# Altium schematic sheet stm32f103fxx.SchDoc(stm32f103fxx)
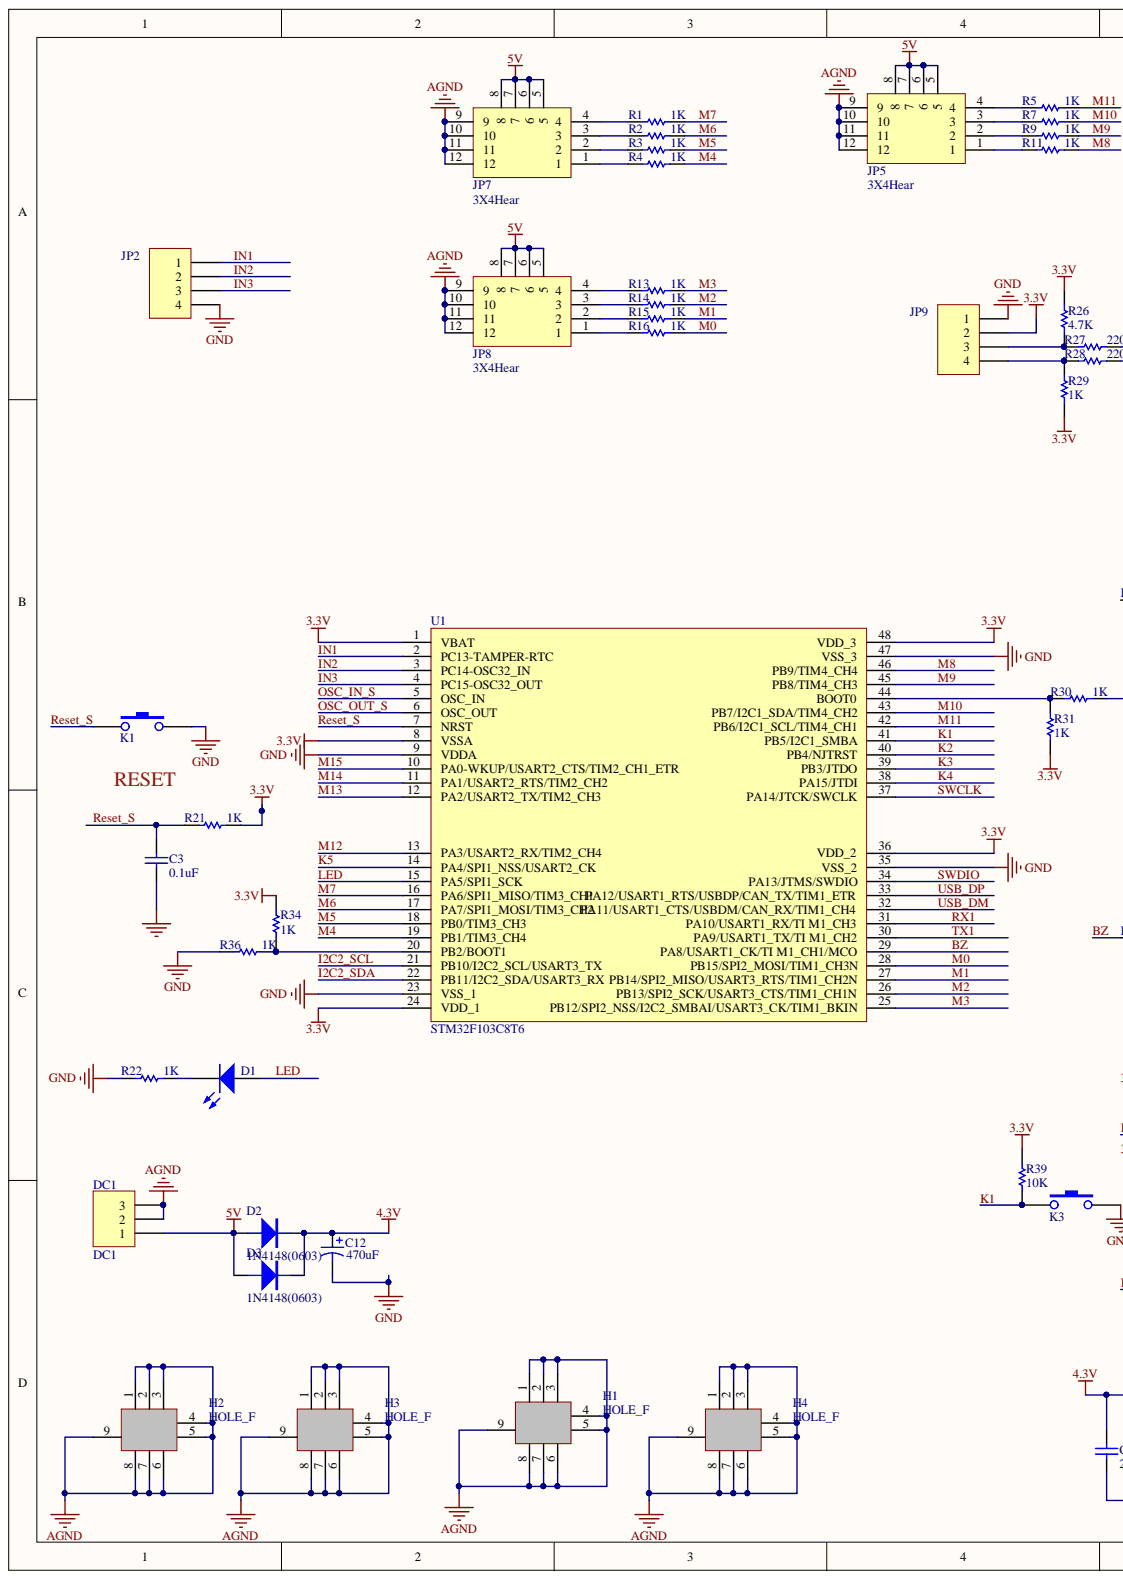
[diagram p0: stm32f103fxx.SchDoc, left]
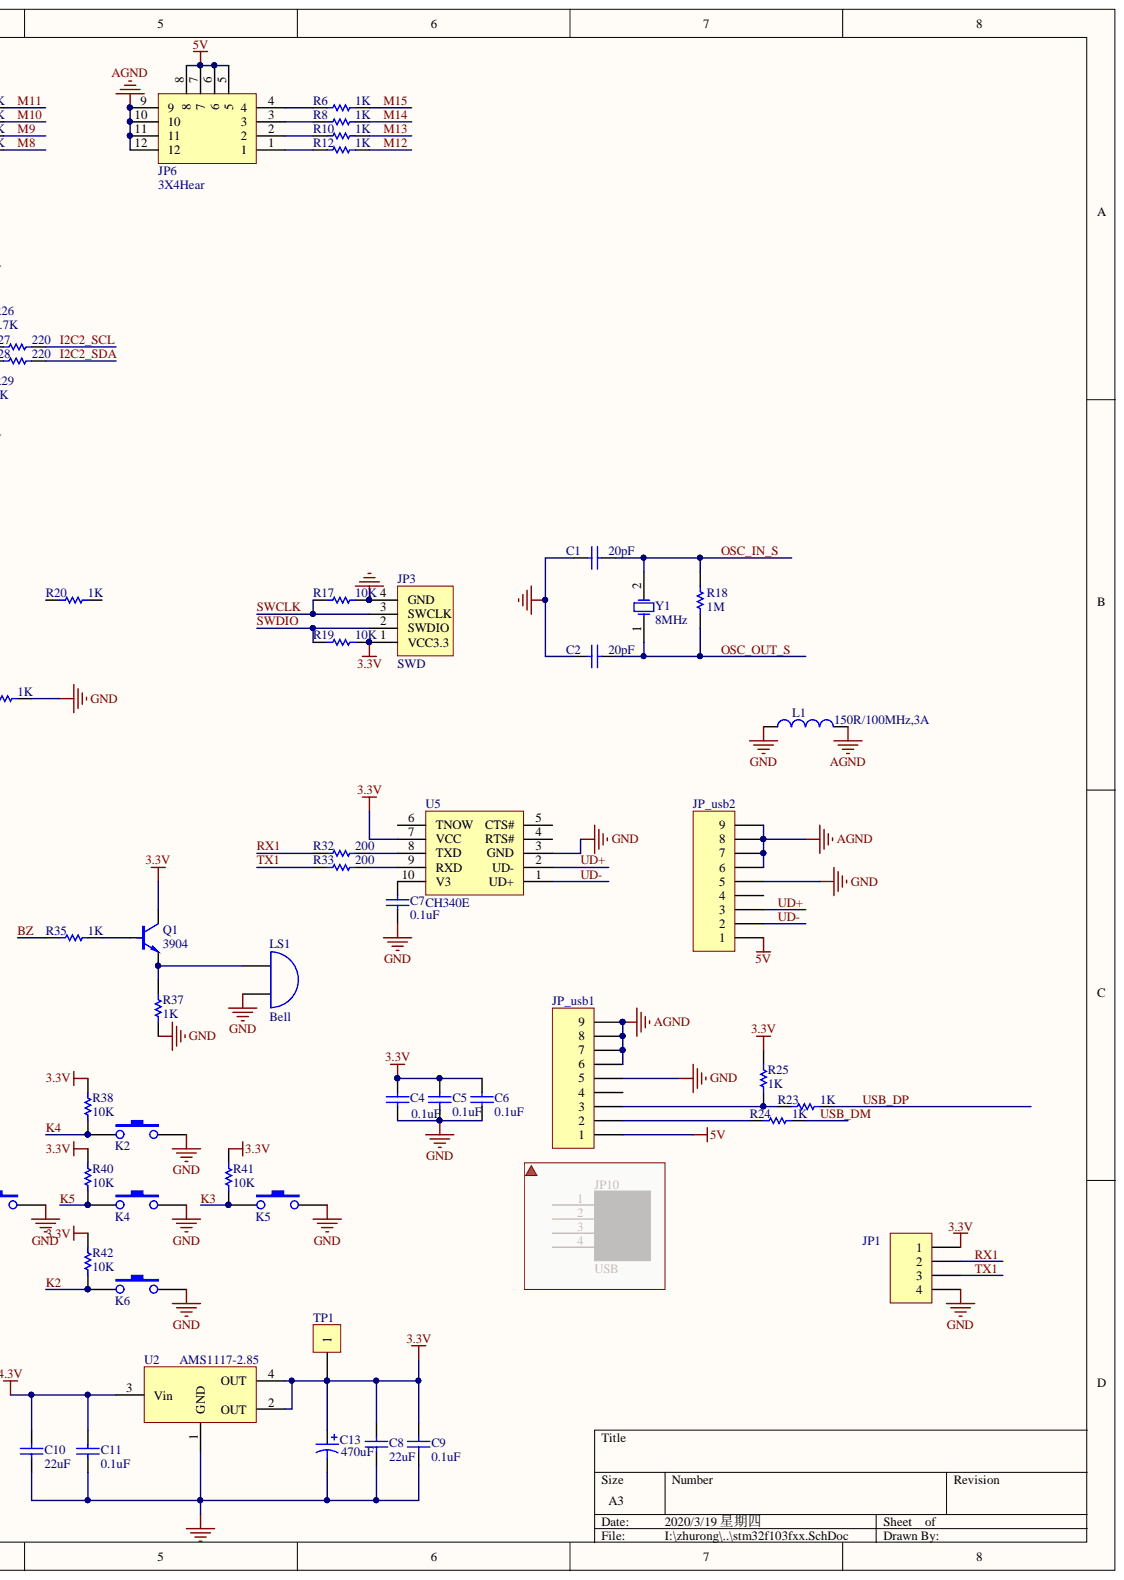
[diagram p1: stm32f103fxx.SchDoc, right]

## Parts
C1  20pF  [Cap-0603]
C2  20pF  [Cap-0603]
C3  0.1uF  [Cap-0603]
C4  0.1uF  [Cap-0603]
C5  0.1uF  [Cap-0603]
C6  0.1uF  [Cap-0603]
C7  0.1uF  [Cap-0603]
C8  22uF  [Res1-0603]
C9  0.1uF  [Cap-0603]
C10  22uF  [0805C]
C11  0.1uF  [Cap-0603]
C12  value 470uF  [RB-L1-6.2X11.2mm]  (hidden comment: Cap Pol1)
C13  value 470uF  [RB-L1-6.2X11.2mm]  (hidden comment: Cap Pol1)
D1  LED0  [0603LED]  (value not drawn)
D2  1N4148(0603)  [SOD-123]
D3  1N4148(0603)  [SOD-123]
DC1  DC1  [DC_5]
H1  HOLE_F  [HOLE]
H2  HOLE_F  [HOLE]
H3  HOLE_F  [HOLE]
H4  HOLE_F  [HOLE]
JP1  Header 4  [JP4]  (value not drawn)
JP2  Header 4  [JP4]  (value not drawn)
JP3  SWD  [JP4]
JP5  3X4Hear  [JP3X4]
JP6  3X4Hear  [JP3X4]
JP7  3X4Hear  [JP3X4]
JP8  3X4Hear  [JP3X4]
JP9  Header 4  [JP4]  (value not drawn)
JP_usb1  Header 9  [USB]  (value not drawn)
JP_usb2  Header 9  [USB]  (value not drawn)
K1  KEY  [TS_B005key]  (value not drawn)
K2  KEY  [TS_B005key]  (value not drawn)
K3  KEY  [TS_B005key]  (value not drawn)
K4  KEY  [TS_B005key]  (value not drawn)
K5  KEY  [TS_B005key]  (value not drawn)
K6  KEY  [TS_B005key]  (value not drawn)
L1  150R/100MHz,3A  [0603L]
LS1  Bell  [PIN2]
Q1  3904  [SOT23]
R1  1K  [Res1-0603]
R2  1K  [Res1-0603]
R3  1K  [Res1-0603]
R4  1K  [Res1-0603]
R5  1K  [Res1-0603]
R6  1K  [Res1-0603]
R7  1K  [Res1-0603]
R8  1K  [Res1-0603]
R9  1K  [Res1-0603]
R10  1K  [Res1-0603]
R11  1K  [Res1-0603]
R12  1K  [Res1-0603]
R13  1K  [Res1-0603]
R14  1K  [Res1-0603]
R15  1K  [Res1-0603]
R16  1K  [Res1-0603]
R17  10K  [Res1-0603]
R18  1M  [Res1-0603]
R19  10K  [Res1-0603]
R20  1K  [Res1-0603]
R21  1K  [Res1-0603]
R22  1K  [Res1-0603]
R23  1K  [Res1-0603]
R24  1K  [Res1-0603]
R25  1K  [Res1-0603]
R26  value 4.7K  [Res1-0603]  (hidden comment: 1K)
R27  value 220  [Res1-0603]  (hidden comment: 200)
R28  1K  value 220  [Res1-0603]
R29  4.7K  value 1K  [Res1-0603]
R30  1K  [Res1-0603]
R31  1K  [Res1-0603]
R32  value 200  [Res1-0603]  (hidden comment: 1K)
R33  value 200  [Res1-0603]  (hidden comment: 1K)
R34  1K  [Res1-0603]
R35  1K  [Res1-0603]
R36  1K  [Res1-0603]
R37  1K  [Res1-0603]
R38  value 10K  [Res1-0603]  (hidden comment: 1K)
R39  value 10K  [Res1-0603]  (hidden comment: 1K)
R40  value 10K  [Res1-0603]  (hidden comment: 1K)
R41  value 10K  [Res1-0603]  (hidden comment: 1K)
R42  value 10K  [Res1-0603]  (hidden comment: 1K)
TP1  TP  [TP]
U1  STM32F103C8T6  [LQFP48/STM]
U2  AMS1117-2.85  [SOT223_AMS1117_GX]
U5  CH340E  [MSOP10]
Y1  8MHz  [XLXT]

## Nets
3.3V: C4.1 C5.1 C6.1 C8.1 C9.2 C13.1 JP1.1 JP3.1(VCC3.3) JP9.2 Q1.C R19.1 R21.1 R25.1 R26.2 R29.1 R31.1 R34.1 R38.2 R39.2 R40.2 R41.2 R42.2 TP1.1 U1.1(VBAT) U1.8(VSSA) U1.24(VDD_1) U1.36(VDD_2) U1.48(VDD_3) U2.2(OUT) U2.4(OUT) U5.7(VCC)
4.3V: C10.1 C11.2 C12.1 D2.2 D3.2 U2.3(Vin)
5V: D2.1 D3.1 DC1.1 JP5.5 JP5.6 JP5.7 JP5.8 JP6.5 JP6.6 JP6.7 JP6.8 JP7.5 JP7.6 JP7.7 JP7.8 JP8.5 JP8.6 JP8.7 JP8.8 JP_usb1.1 JP_usb2.1
AGND: DC1.2 DC1.3 H1.1 H1.2 H1.3 H1.4 H1.5 H1.6 H1.7 H1.8 H1.9 H2.1 H2.2 H2.3 H2.4 H2.5 H2.6 H2.7 H2.8 H2.9 H3.1 H3.2 H3.3 H3.4 H3.5 H3.6 H3.7 H3.8 H3.9 H4.1 H4.2 H4.3 H4.4 H4.5 H4.6 H4.7 H4.8 H4.9 JP5.9 JP5.10 JP5.11 JP5.12 JP6.9 JP6.10 JP6.11 JP6.12 JP7.9 JP7.10 JP7.11 JP7.12 JP8.9 JP8.10 JP8.11 JP8.12 JP_usb1.6 JP_usb1.7 JP_usb1.8 JP_usb1.9 JP_usb2.6 JP_usb2.7 JP_usb2.8 JP_usb2.9 L1.2
GND: C1.1 C2.1 C3.2 C4.2 C5.2 C6.2 C7.2 C8.2 C9.1 C10.2 C11.1 C12.2 C13.2 JP1.4 JP2.4 JP3.4(GND) JP9.1 JP_usb1.5 JP_usb2.5 K1.2 K2.2 K3.2 K4.2 K5.2 K6.2 L1.1 LS1.2 R17.1 R22.1 R30.2 R36.2 R37.1 U1.9(VDDA) U1.23(VSS_1) U1.35(VSS_2) U1.47(VSS_3) U2.1(GND) U5.3(GND)
BZ: R35.1 U1.29(PA8/USART1_CK/TI_M1_CH1/MCO)
I2C2_SCL: R27.2 U1.21(PB10/I2C2_SCL/USART3_TX)
I2C2_SDA: R28.2 U1.22(PB11/I2C2_SDA/USART3_RX)
IN1: JP2.1 U1.2(PC13-TAMPER-RTC)
IN2: JP2.2 U1.3(PC14-OSC32_IN)
IN3: JP2.3 U1.4(PC15-OSC32_OUT)
K1: K3.1 R39.1 U1.41(PB5/I2C1_SMBA)
K2: K6.1 R42.1 U1.40(PB4/NJTRST)
K3: K5.1 R41.1 U1.39(PB3/JTDO)
K4: K2.1 R38.1 U1.38(PA15/JTDI)
K5: K4.1 R40.1 U1.14(PA4/SPI1_NSS/USART2_CK)
LED: D1.1 U1.15(PA5/SPI1_SCK)
M0: R16.2 U1.28(PB15/SPI2_MOSI/TIM1_CH3N)
M1: R15.2 U1.27(PB14/SPI2_MISO/USART3_RTS/TIM1_CH2N)
M2: R14.2 U1.26(PB13/SPI2_SCK/USART3_CTS/TIM1_CH1N)
M3: R13.2 U1.25(PB12/SPI2_NSS/I2C2_SMBAI/USART3_CK/TIM1_BKIN)
M4: R4.2 U1.19(PB1/TIM3_CH4)
M5: R3.2 U1.18(PB0/TIM3_CH3)
M6: R2.2 U1.17(PA7/SPI1_MOSI/TIM3_CH2)
M7: R1.2 U1.16(PA6/SPI1_MISO/TIM3_CH1)
M8: R11.2 U1.46(PB9/TIM4_CH4)
M9: R9.2 U1.45(PB8/TIM4_CH3)
M10: R7.2 U1.43(PB7/I2C1_SDA/TIM4_CH2)
M11: R5.2 U1.42(PB6/I2C1_SCL/TIM4_CH1)
M12: R12.2 U1.13(PA3/USART2_RX/TIM2_CH4)
M13: R10.2 U1.12(PA2/USART2_TX/TIM2_CH3)
M14: R8.2 U1.11(PA1/USART2_RTS/TIM2_CH2)
M15: R6.2 U1.10(PA0-WKUP/USART2_CTS/TIM2_CH1_ETR)
OSC_IN_S: C1.2 R18.2 U1.5(OSC_IN) Y1.2
OSC_OUT_S: C2.2 R18.1 U1.6(OSC_OUT) Y1.1
RESET_S: C3.1 K1.1 R21.2 U1.7(NRST)
RX1: JP1.2 R32.1 U1.31(PA10/USART1_RX/TI_M1_CH3)
SWCLK: JP3.3(SWCLK) R17.2 U1.37(PA14/JTCK/SWCLK)
SWDIO: JP3.2(SWDIO) R19.2 U1.34(PA13/JTMS/SWDIO)
TX1: JP1.3 R33.1 U1.30(PA9/USART1_TX/TI_M1_CH2)
UD+: JP_usb2.3 U5.2(UD-)
UD-: JP_usb2.2 U5.1(UD+)
USB_DM: R24.2 U1.32(PA11/USART1_CTS/USBDM/CAN_RX/TIM1_CH4)
USB_DP: R23.2 U1.33(PA12/USART1_RTS/USBDP/CAN_TX/TIM1_ETR)
NetC7_1: C7.1 U5.10(V3)
NetD1_2: D1.2 R22.2
NetJP5_1: JP5.1 R11.1
NetJP5_2: JP5.2 R9.1
NetJP5_3: JP5.3 R7.1
NetJP5_4: JP5.4 R5.1
NetJP6_1: JP6.1 R12.1
NetJP6_2: JP6.2 R10.1
NetJP6_3: JP6.3 R8.1
NetJP6_4: JP6.4 R6.1
NetJP7_1: JP7.1 R4.1
NetJP7_2: JP7.2 R3.1
NetJP7_3: JP7.3 R2.1
NetJP7_4: JP7.4 R1.1
NetJP8_1: JP8.1 R16.1
NetJP8_2: JP8.2 R15.1
NetJP8_3: JP8.3 R14.1
NetJP8_4: JP8.4 R13.1
NetJP9_3: JP9.3 R26.1 R27.1
NetJP9_4: JP9.4 R28.1 R29.2
NetJP_usb1_2: JP_usb1.2 R24.1
NetJP_usb1_3: JP_usb1.3 R23.1 R25.2
NetLS1_1: LS1.1 Q1.E R37.2
NetQ1_B: Q1.B R35.2
NetR30_1: R30.1 R31.2 U1.44(BOOT0)
NetR32_2: R32.2 U5.8(TXD)
NetR33_2: R33.2 U5.9(RXD)
NetR34_2: R34.2 R36.1 U1.20(PB2/BOOT1)

Not connected: JP_usb1 4; JP_usb2 4; R20 1 2; U5 4(RTS#) 5(CTS#) 6(TNOW)

## Library data of the parts
C1-C11 = Cap Semi: Component Kind: Standard; LatestRevisionDate: 29-May-2009; LatestRevisionNote: IPC-7351 Footprint Added.; Library Name: Miscellaneous Devices.IntLib; PackageDescription: Chip Capacitor; PackageReference: C1206; Published: 8-Jun-2000; Signal Integrity: Cap Semi; Simulation: CAP; Publisher: Altium Limited
  C1 C2: Description: 20pF; Value: 20pF
  C3-C7 C9 C11: Description: 0.1uF; Value: 0.1uF
  C8 C10: Description: 22uF; Value: 22uF
C12 C13 = Cap Pol1: Polarized Capacitor (Radial); Component Kind: Standard; LatestRevisionDate: 17-Jul-2002; LatestRevisionNote: Re-released for DXP Platform.; Library Name: Miscellaneous Devices.IntLib; PackageReference: RB7.6-15; Published: 8-Jun-2000; Signal Integrity: Cap Pol; Simulation: CAP; Publisher: Altium Limited
D1 = LED0: Typical INFRARED GaAs LED; Component Kind: Standard; LatestRevisionDate: 08-Jul-2005; LatestRevisionNote: Mech Layer 1 removed.; Library Name: Miscellaneous Devices.IntLib; PackageReference: LED-0; Published: 8-Jun-2000; Simulation: LED0; Publisher: Altium Limited
DC1 = Header 3: Header, 3-Pin; Component Kind: Standard; LatestRevisionDate: 17-Jul-2002; LatestRevisionNote: Re-released for DXP Platform.; Library Name: KBMC100-AB92A.IntLib; Publisher: Altium Limited
JP1 JP2 JP9 = Header 4: Header, 4-Pin; Component Kind: Standard; LatestRevisionDate: 17-Jul-2002; LatestRevisionNote: Re-released for DXP Platform.; Library Name: Miscellaneous Connectors.IntLib; Publisher: Altium Limited
JP3 = SWD: JTAG_SWD; : SWD; Component Kind: Standard; Library Name: 我的元件库.SCHLIB
JP_usb1 JP_usb2 = Header 9: Header, 9-Pin; Component Kind: Standard; LatestRevisionDate: 17-Jul-2002; LatestRevisionNote: Re-released for DXP Platform.; Library Name: Miscellaneous Connectors.IntLib; Publisher: Altium Limited
K1-K6 = SW-PB: Component Kind: Standard; Description: KEY
L1 = Inductor: Code_IEC: 1005; Code_JEITA: 0402; Component Kind: Standard; Description: 150R/100MHz,3A; LatestRevisionDate: 29-May-2009; LatestRevisionNote: IPC-7351 Footprint Added.; Library Name: Miscellaneous Devices.IntLib; PackageDescription: Chip Inductor; PackageReference: 0402-A; Published: 8-Jun-2000; Signal Integrity: Inductor; Simulation: INDUCTOR; Value: 150R/100MHz,3A; Publisher: Altium Limited
LS1 = Bell: Electrical Bell; Component Kind: Standard; LatestRevisionDate: 17-Jul-2002; LatestRevisionNote: Re-released for DXP Platform.; Library Name: Miscellaneous Devices.IntLib; PackageReference: PIN2; Published: 24-Mar-1999; Publisher: Altium Limited
Q1 = NPN: Component Kind: Standard; Library Name: KBAS100-AA41C.IntLib
R1-R26 R28 R30-R42 = Res1: Component Kind: Standard; LatestRevisionDate: 17-Jul-2002; LatestRevisionNote: Re-released for DXP Platform.; Library Name: Miscellaneous Devices.IntLib; PackageReference: AXIAL-0.3; Published: 8-Jun-2000; Signal Integrity: Res; Simulation: RESISTOR; Publisher: Altium Limited
  R1-R16 R20-R25 R30 R31 R34-R37: Description: 1K; Value: 1K
  R17 R19: Description: 10K; Value: 10K
  R18: Description: 1M; Value: 1M
  R26 R28 R32 R33 R38-R42: Description: 1K
R27 R29 = Res1: 1K; Component Kind: Standard; LatestRevisionDate: 17-Jul-2002; LatestRevisionNote: Re-released for DXP Platform.; Library Name: Miscellaneous Devices.IntLib; PackageReference: AXIAL-0.3; Published: 8-Jun-2000; Signal Integrity: Res; Simulation: RESISTOR; Publisher: Altium Limited
TP1 = TP: Test point; Component Kind: Standard; Library Name: huasu_wzw.SchLib
U1 = STMF103CB: STM103FCB; Component Kind: Standard; Package: LQFP48; Value: STM103FCB; Library Name: huasu_wzw.SchLib
U2 = ASM1117: Component Kind: Standard; Description: AMS1117-2.85; Package: SOT223; Library Name: huasu_wzw.SchLib
Y1 = XTAL: Component Kind: Standard; Description: 8MHz; LatestRevisionDate: 17-Jul-2002; LatestRevisionNote: Re-released for DXP Platform.; Library Name: Miscellaneous Devices.IntLib; PackageReference: R38; Published: 8-Jun-2000; Value: 8MHz; Publisher: Altium Limited
D2 D3 = 1N4148(0603): Component Kind: Standard; Library Name: 我的元件库.SCHLIB
H1-H4 = HOLE_F: Component Kind: Standard; Library Name: huasu_wzw.SchLib
JP5-JP8 = 3X4Hear: Component Kind: Standard; Library Name: pwmProject.SCHLIB
U5 = CH340E: Component Kind: Standard; Library Name: pwmProject.SCHLIB
